# Altium schematic sheet Main.SchDoc(Main)
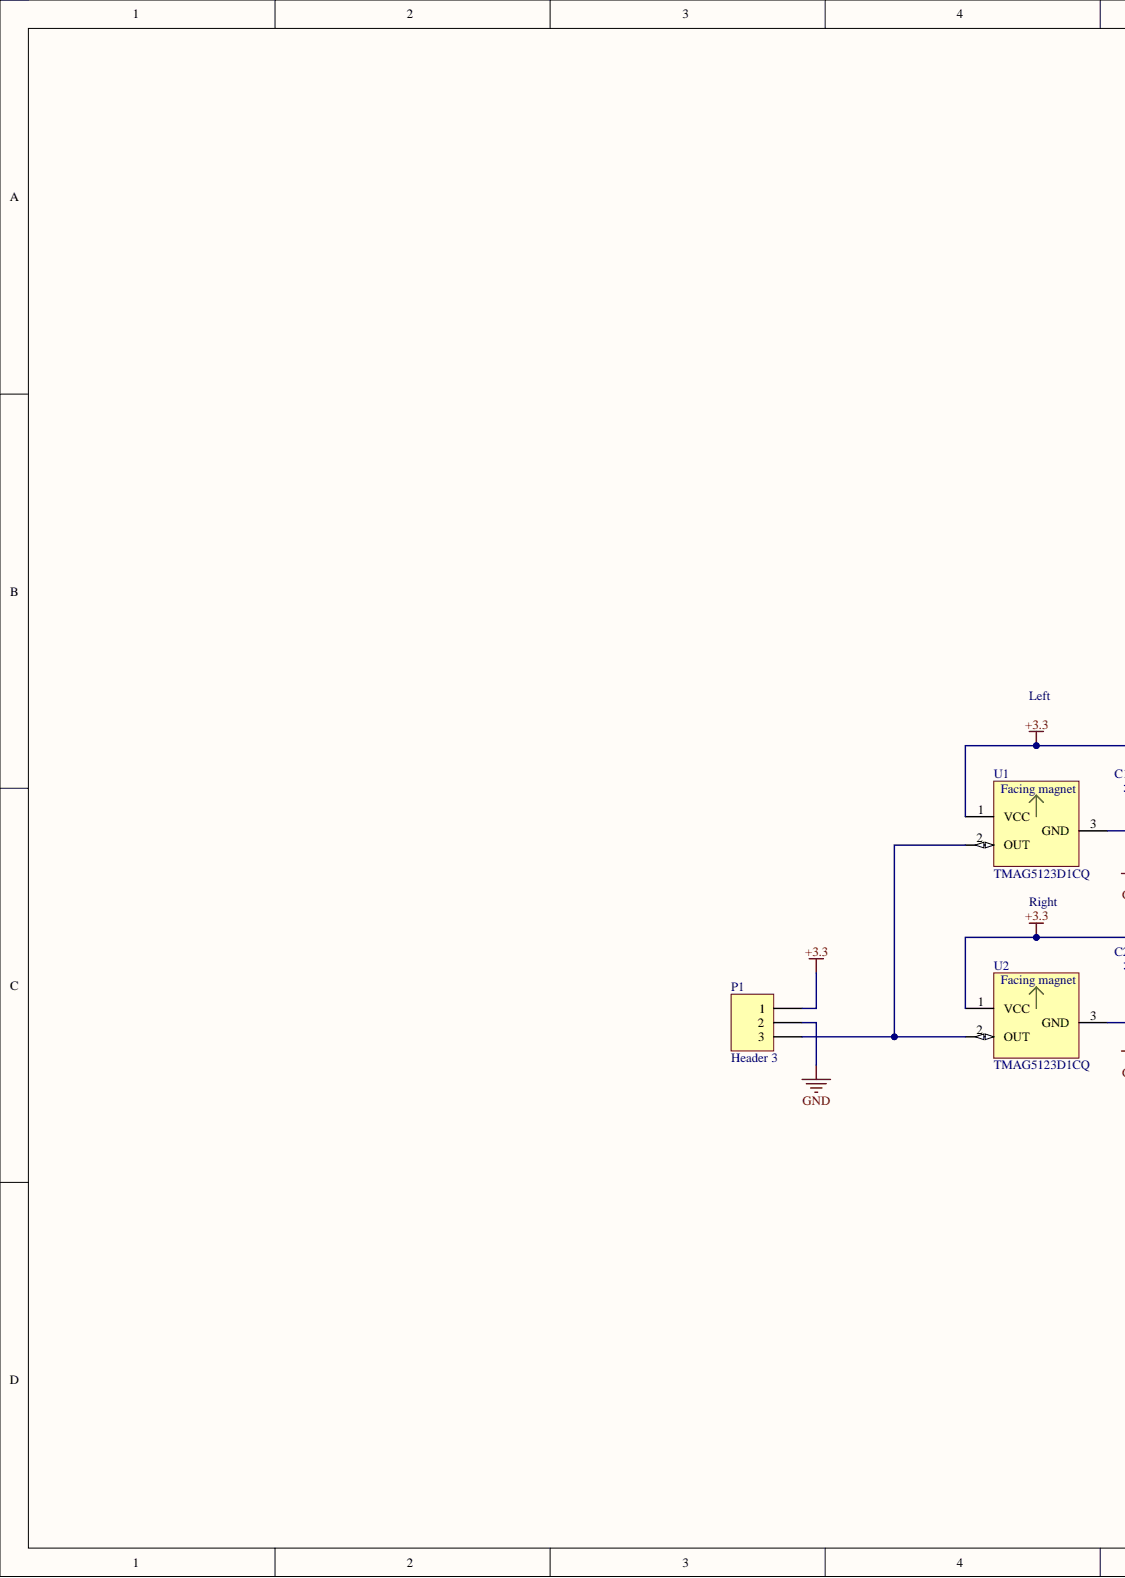
[diagram p0: Main.SchDoc, left]
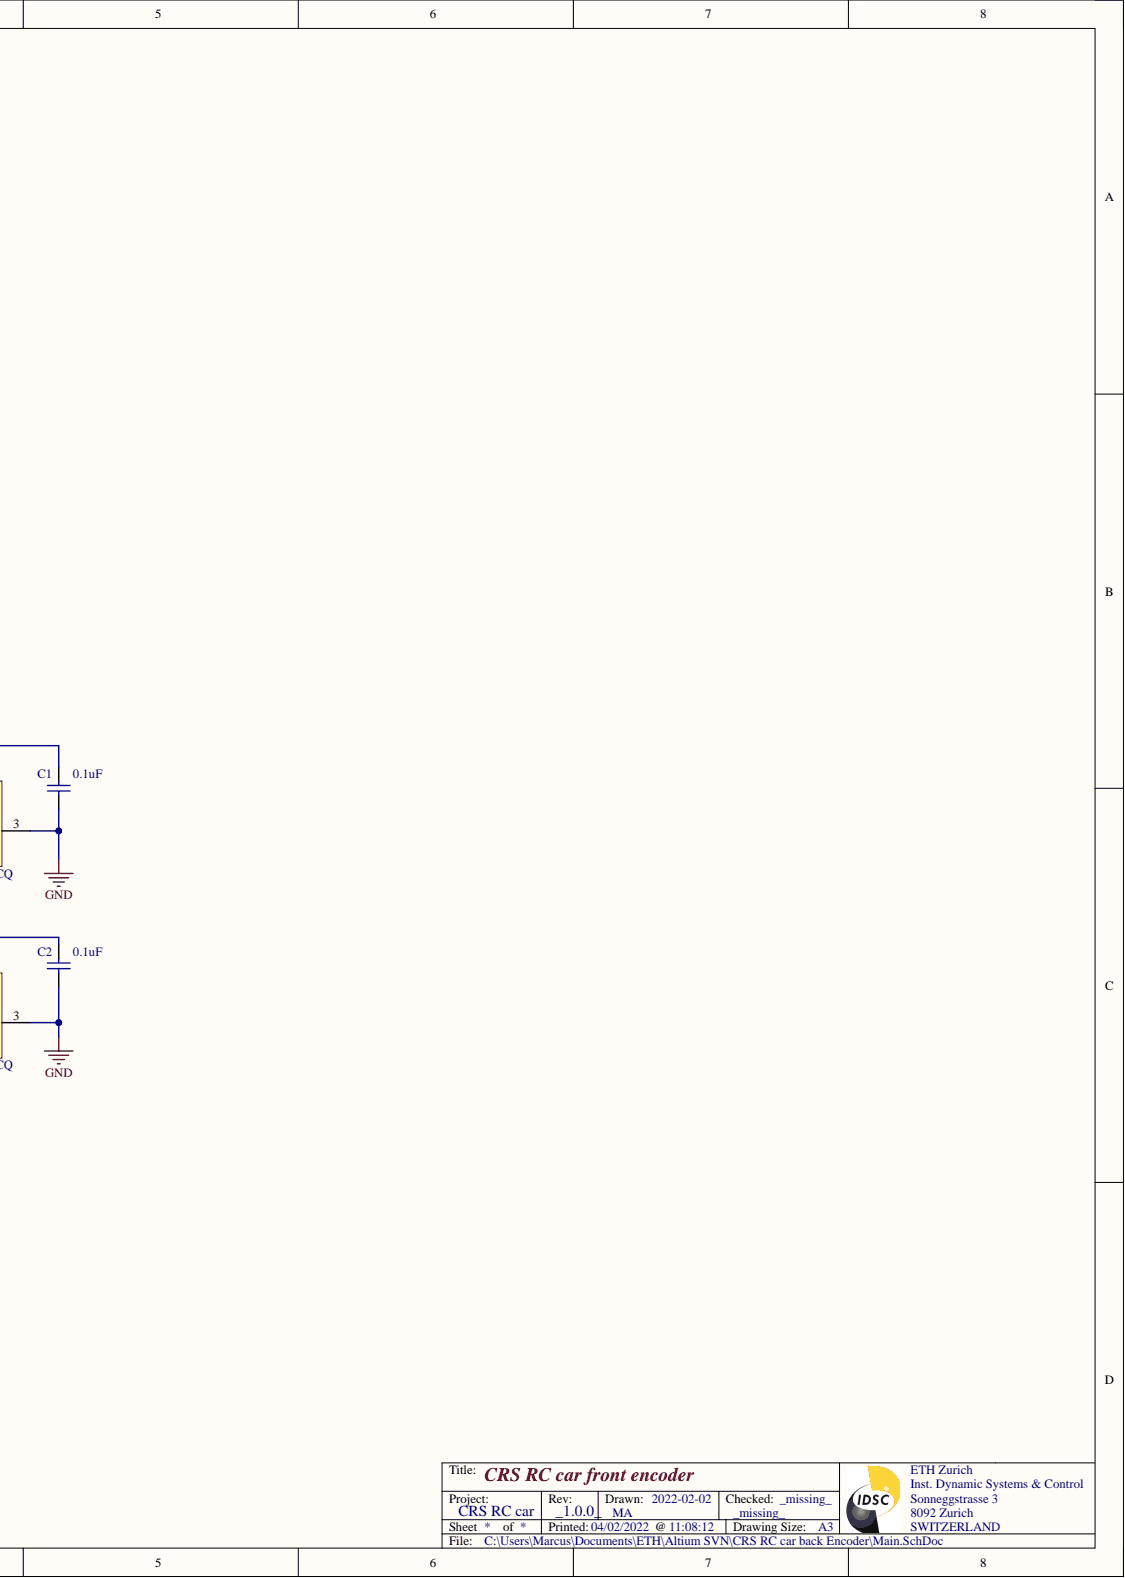
[diagram p1: Main.SchDoc, right]

## Parts
C1  0.1uF  [CAP_0603i_1608m -N]
C2  0.1uF  [CAP_0603i_1608m -N]
P1  Header 3  [1.27mm HDR1X3]
U1  TMAG5123D1CQ  [SOT23-N]
U2  TMAG5123D1CQ  [SOT23-N]

## Nets
+3.3: C1.1 C2.1 P1.1 U1.1 U2.1
GND: C1.2 C2.2 P1.2 U1.3 U2.3
NetP1_3: P1.3 U1.2 U2.2

## Library data of the parts
C1 C2 = Cap: capacitor; Component Kind: Standard; Signal Integrity: Cap; Simulation: CAPACITOR; Supplier 1: Digi-Key; Supplier Part Number 1: 1276-1006-1-ND; Library Name: IDSC std generic parts.IntLib
P1 = Header 3: Header, 3-Pin; Component Kind: Standard; Signal Integrity: Connector; Library Name: IDSC std connectors.IntLib
U1 U2 = TMAG5123D1CQ: Board Mount Hall Effect/Magnetic Sensors High-voltage (up to 38 V), in-plane, high-precision switch; Component Kind: Standard; Supplier 1: Digi-Key; Supplier Part Number 1: 296-TMAG5123D1CQDBZRCT-ND; Library Name: IDSC_component_lib.IntLib
